# Altium schematic sheet FH@Tbadge.SchDoc
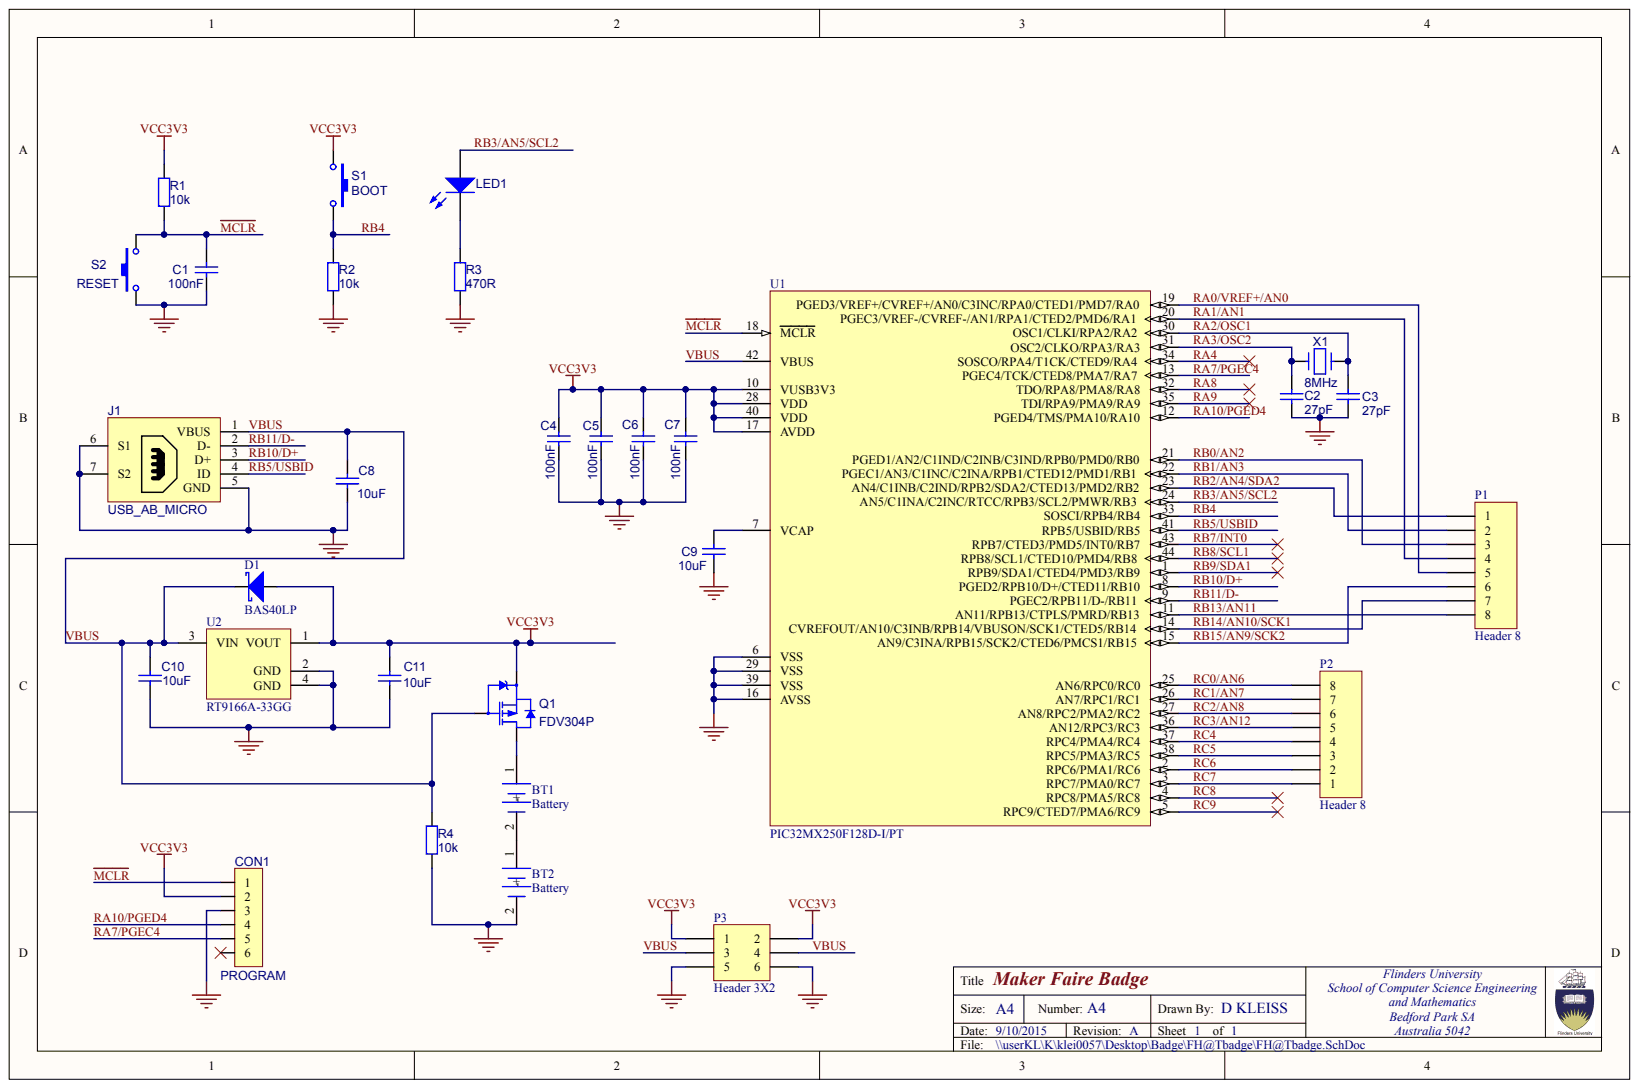
[diagram p0: FH@Tbadge.SchDoc]

## Parts
BT1  Battery  [BatteryAAA55N]
BT2  Battery  [BatteryAAA55N]
C1  100nF  [0805 Reflow]
C2  27pF  [0805 Reflow]
C3  27pF  [0805 Reflow]
C4  100nF  [0805 Reflow]
C5  100nF  [0805 Reflow]
C6  100nF  [0805 Reflow]
C7  100nF  [0805 Reflow]
C8  10uF  [0805 Reflow]
C9  10uF  [0805 Reflow]
C10  10uF  [0805 Reflow]
C11  10uF  [0805 Reflow]
CON1  PROGRAM  [HDR1X6 - Offset Pins]
D1  BAS40LP  [SOT-23]
J1  USB_AB_MICRO  [USB MICRO-AB SMD]
LED1  #NAME?  [LED 3.2 x 1.6mm]  (value not drawn)
P1  Header 8  [Header SMD]
P2  Header 8  [Header SMD]
P3  Header 3X2  [HDR2X3]
Q1  FDV304P  [SOT-23]
R1  10k  [0805 Reflow]
R2  10k  [0805 Reflow]
R3  470R  [0805 Reflow]
R4  10k  [0805 Reflow]
S1  BOOT  [DTSM SWITCH]
S2  RESET  [DTSM SWITCH]
U1  PIC32MX250F128D-I/PT  [MCHP-TQFP-PT44_M]  (value not drawn)
U2  RT9166A-33GG  [SOT-223]
X1  8MHz  [CRYSTAL HC49 SMD]

## Nets
GND: BT2.2 C1.2 C2.2 C3.2 C4.2 C5.2 C6.2 C7.2 C8.2 C9.2 C10.2 C11.2 CON1.3 J1.5(GND) J1.6(S1) J1.7(S2) P3.5 P3.6 R2.2 R3.2 R4.2 S2.2 U1.6(VSS) U1.16(AVSS) U1.29(VSS) U1.39(VSS) U2.2(GND) U2.4(GND)
VCC3V3: C4.1 C5.1 C6.1 C7.1 C11.1 CON1.2 D1.1 P3.1 P3.2 Q1.2 R1.1 S1.2 U1.10(VUSB3V3) U1.17(AVDD) U1.28(VDD) U1.40(VDD) U2.1(VOUT)
~{MCLR}: C1.1 CON1.1 R1.2 S2.1 U1.18(MCLR)
RA0/VREF+/AN0: P1.5 U1.19(PGED3/VREF+/CVREF+/AN0/C3INC/RPA0/CTED1/PMD7/RA0)
RA1/AN1: P1.4 U1.20(PGEC3/VREF-/CVREF-/AN1/RPA1/CTED2/PMD6/RA1)
RA2/OSC1: C3.1 U1.30(OSC1/CLKI/RPA2/RA2) X1.2
RA3/OSC2: C2.1 U1.31(OSC2/CLKO/RPA3/RA3) X1.1
RA4: U1.34(SOSCO/RPA4/T1CK/CTED9/RA4)
RA7/PGEC4: CON1.5 U1.13(PGEC4/TCK/CTED8/PMA7/RA7)
RA8: U1.32(TDO/RPA8/PMA8/RA8)
RA9: U1.35(TDI/RPA9/PMA9/RA9)
RA10/PGED4: CON1.4 U1.12(PGED4/TMS/PMA10/RA10)
RB0/AN2: P1.3 U1.21(PGED1/AN2/C1IND/C2INB/C3IND/RPB0/PMD0/RB0)
RB1/AN3: P1.2 U1.22(PGEC1/AN3/C1INC/C2INA/RPB1/CTED12/PMD1/RB1)
RB2/AN4/SDA2: P1.1 U1.23(AN4/C1INB/C2IND/RPB2/SDA2/CTED13/PMD2/RB2)
RB3/AN5/SCL2: LED1.A U1.24(AN5/C1INA/C2INC/RTCC/RPB3/SCL2/PMWR/RB3)
RB4: R2.1 S1.1 U1.33(SOSCI/RPB4/RB4)
RB5/USBID: J1.4(ID) U1.41(RPB5/USBID/RB5)
RB7/INT0: U1.43(RPB7/CTED3/PMD5/INT0/RB7)
RB8/SCL1: U1.44(RPB8/SCL1/CTED10/PMD4/RB8)
RB9/SDA1: U1.1(RPB9/SDA1/CTED4/PMD3/RB9)
RB10/D+: J1.3(D+) U1.8(PGED2/RPB10/D+/CTED11/RB10)
RB11/D-: J1.2(D-) U1.9(PGEC2/RPB11/D-/RB11)
RB13/AN11: P1.8 U1.11(AN11/RPB13/CTPLS/PMRD/RB13)
RB14/AN10/SCK1: P1.7 U1.14(CVREFOUT/AN10/C3INB/RPB14/VBUSON/SCK1/CTED5/RB14)
RB15/AN9/SCK2: P1.6 U1.15(AN9/C3INA/RPB15/SCK2/CTED6/PMCS1/RB15)
RC0/AN6: P2.8 U1.25(AN6/RPC0/RC0)
RC1/AN7: P2.7 U1.26(AN7/RPC1/RC1)
RC2/AN8: P2.6 U1.27(AN8/RPC2/PMA2/RC2)
RC3/AN12: P2.5 U1.36(AN12/RPC3/RC3)
RC4: P2.4 U1.37(RPC4/PMA4/RC4)
RC5: P2.3 U1.38(RPC5/PMA3/RC5)
RC6: P2.2 U1.2(RPC6/PMA1/RC6)
RC7: P2.1 U1.3(RPC7/PMA0/RC7)
RC8: U1.4(RPC8/PMA5/RC8)
RC9: U1.5(RPC9/CTED7/PMA6/RC9)
VBUS: C8.1 C10.1 D1.3 J1.1(VBUS) P3.3 P3.4 Q1.1 R4.1 U1.42(VBUS) U2.3(VIN)
NetBT1_1: BT1.1 Q1.3
NetBT1_2: BT1.2 BT2.1
NetC9_1: C9.1 U1.7(VCAP)
NetLED1_K: LED1.K R3.1

Not connected: CON1 6

## Library data of the parts
BT1 BT2 = Battery: Multicell Battery; Component Kind: Standard; PackageReference: BAT-2; Library Name: Workshop Library 2010_06_24.SchLib
C1-C8 = Cap: Capacitor; Component Kind: Standard; Signal Integrity: Cap; Simulation: CAP; Library Name: Workshop Library 2010_06_24.SchLib
  C1 C4-C7: Value: 100nF
  C2 C3: Value: 27pF
  C8: Value: 10uF
C9-C11 = Cap: Capacitor; Value: 10uF 10V; Component Kind: Standard; Element 14 Part Number: 1759421RL; Signal Integrity: Cap; Simulation: CAP; Library Name: Workshop Library 2010_06_24.SchLib
CON1 = CON6: Header, 6-Pin; Component Kind: Standard; Revision: 17-Jul-2002: Re-released for DXP Platform.; Signal Integrity: Connector; Library Name: Workshop Library 2010_06_24.SchLib
D1 = BAS40LP: SURFACE MOUNT SCHOTTKY BARRIER DIODE; Component Kind: Standard; Library Name: Workshop Library 2010_06_24.SchLib
J1 = CON_USB_MICRO_AB: Micro USB AB Receptacle; Manufacturer: Molex; Component Kind: Standard; Element 14 Part Number: 1568022; Library Name: Workshop Library 2010_06_24.SchLib
LED1 = LED: Component Kind: Standard; Description: LED; Simulation: LED3; Library Name: Workshop Library 2010_06_24.SchLib
P1 P2 = Header 8: Header, 8-Pin; Component Kind: Standard; Signal Integrity: Connector; Library Name: Workshop Library 2010_06_24.SchLib
P3 = Header 3X2: Header, 3-Pin, Dual row; Component Kind: Standard; LatestRevisionDate: 17-Jul-2002; LatestRevisionNote: Re-released for DXP Platform.; Library Name: Miscellaneous Connectors.IntLib; Signal Integrity: Connector; Publisher: Altium Limited
Q1 = FDV304P: Digital FET, P-Channel; Manufacturer: Fairchild; Component Kind: Standard; Library Name: Workshop Library 2010_06_24.SchLib
R1-R4 = Resistor: Component Kind: Standard; Description: Resistor; Signal Integrity: Res; Simulation: RESISTOR; Library Name: Workshop Library 2010_06_24.SchLib
  R1 R2 R4: Value: 10k
  R3: Value: 470R
S1 S2 = SW-PB: Switch; Manufacturer: ALPS; Manufacturer Number: SKHHLPA010; Component Kind: Standard; Element 14 Part Number: 1656428; Library Name: Workshop Library 2010_06_24.SchLib
U1 = PIC32MX250F128D-I/PT: 32-bit Microcontroller with Audio and Graphics Interface, USB and Advanced Analog, 50 MHz, 33 I/O, PMP, -40 to 85 degC, 44-pin TQFP (PT44), Tray; Manufacturer: Microchip; Component Kind: Standard; ComponentLink1Description: Manufacturer URL; ComponentLink1URL: http://www.microchip.com; ComponentLink2Description: Datasheet; ComponentLink2URL: http://ww1.microchip.com/downloads/en/DeviceDoc/61168E.pdf; ComponentLink3Description: Package Specification; ComponentLink3URL: http://www.microchip.com/stellent/groups/techpub_sg/documents/packagingspec/en012702.pdf; ComponentLink4Description: Product URL; ComponentLink4URL: http://www.microchip.com/PIC32MX250F128D; DatasheetVersion: Rev. E; Mounting Technology: Surface Mount; PackageDescription: 44-Lead Plastic Thin Quad Flatpack, 10 x 10 mm Body, 0.8 mm Pitch; PackageReference: TQFP-PT44; PackageVersion: C04-076B; PartNumber: PIC32MX250F128D-I/PT; Supplier 1: Digi-Key; Supplier 2: Farnell; Supplier 3: Farnell; Supplier 4: Newark; Supplier 5: Mouser; Supplier Part Number 1: PIC32MX250F128D-I/PT-ND; Supplier Part Number 2: 2097775; Supplier Part Number 3: 2096413; Supplier Part Number 4: 73T1470; Supplier Part Number 5: 579-32MX250F128DIPT; Library Name: Workshop Library 2010_06_24.SchLib
U2 = RT9166A-33GG: 600 mA, 3V3, LDO Regulator, 5-Pin SOT-223-4; Manufacturer: Richtek; Component Kind: Standard; PartNumber: RT9166A-33GG; Library Name: Workshop Library 2010_06_24.SchLib
X1 = CRYSTAL: Component Kind: Standard; Description: Crystal; Value: 8MHz; Library Name: Workshop Library 2010_06_24.SchLib
